# Altium schematic sheet vraimenthorspcb.SchDoc
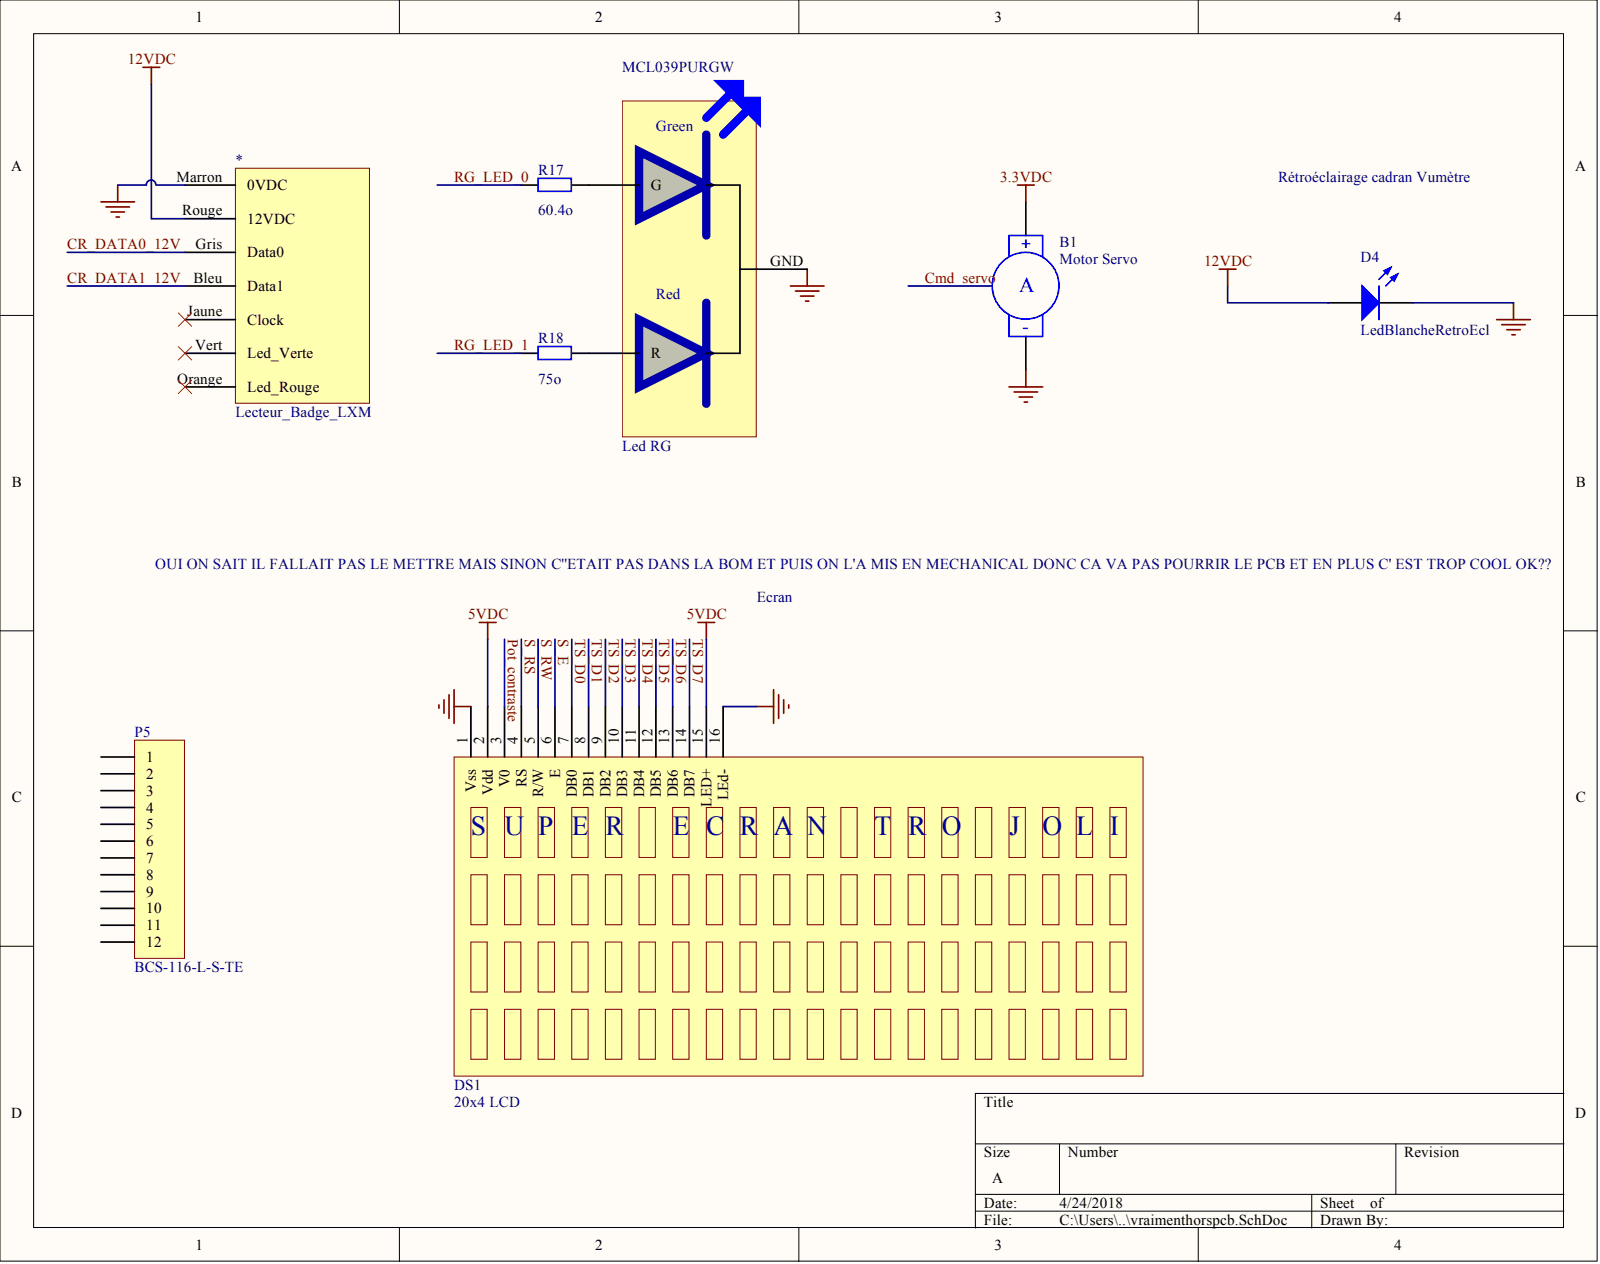
[diagram p0: vraimenthorspcb.SchDoc]

## Parts
*  Lecteur_Badge_LXM  (mechanical)
B1  Motor Servo  [RAD-0.4]  (mechanical)
D4  LedBlancheRetroEcl  [LED-0]  (mechanical)
DS1  20x4 LCD  (mechanical)
MCL039PURGW  Led RG  (mechanical)
P5  BCS-116-L-S-TE  [HDR1X12]  (mechanical)
R17  value 60.4o  [AXIAL-0.4]  (hidden comment: Res2)
R18  value 75o  [AXIAL-0.4]  (hidden comment: Res2)

## Nets
3.3VDC: B1.1
5VDC: DS1.2(Vdd) DS1.15(LED+)
12VDC: *.Rouge(12VDC) D4.1
GND: *.Marron(0VDC) B1.2 D4.2 DS1.1(Vss) DS1.16(LEd-) MCL039PURGW.GND
CR_DATA0_12V: *.Gris(Data0)
CR_DATA1_12V: *.Bleu(Data1)
POT_CONTRASTE: DS1.3(V0)
RG_LED_0: R17.1
RG_LED_1: R18.1
S_E: DS1.6(E)
S_RS: DS1.4(RS)
S_RW: DS1.5(R/W)
TS_D0: DS1.7(DB0)
TS_D1: DS1.8(DB1)
TS_D2: DS1.9(DB2)
TS_D3: DS1.10(DB3)
TS_D4: DS1.11(DB4)
TS_D5: DS1.12(DB5)
TS_D6: DS1.13(DB6)
TS_D7: DS1.14(DB7)
NetMCL039PURGW_G: MCL039PURGW.G R17.2
NetMCL039PURGW_R: MCL039PURGW.R R18.2
CMD_SERVO:

Not connected: * Jaune(Clock) Orange(Led_Rouge) Vert(Led_Verte); P5 1 2 3 4 5 6 7 8 9 10 11 12

## Library data of the parts
* = Lecteur_Badge_LXM: Fabricant: Stid-Security; Fournisseur: Gregoire; Ref.Fabricant: LXM_WIEGAND44b; Ref.Fournisseur: :); Library Name: Schlib1.SchLib
B1 = Motor Servo: Servo Motor; Fabricant: Olimex; Ref.Fabricant: MS-R-1.3-9; Fournisseur: Olimex; Library Name: Miscellaneous Devices.IntLib; Ref.Fournisseur: MS-R-1.3-9
D4 = LED0: LED Blanche retro vumetre; Fournisseur: BARBIE; Fabricant: N/A; Library Name: Miscellaneous Devices.IntLib; Ref.Fabricant: N/A; Ref.Fournisseur: N/A; Simulation: LED0
DS1 = MC42008A6W-FPTLW: Fabricant: Midas; Fournisseur: Farnell; Ref.Fournisseur: 2606880; Library Name: Schlib1.SchLib; Ref.Fabricant: MC42008A6W-FPTLW
MCL039PURGW = MCL039PURGW: Fabricant: Multicomp; Fournisseur: Farnell; Ref.Fournisseur: 1581194; Description: Led RG; Library Name: Schlib1.SchLib; Ref.Fabricant: MCL039PURGW
P5 = Header 12: Header, 12-Pin; Fabricant: Samtec; Fournisseur: Farnell; Ref.Fournisseur: 1667483; Library Name: Miscellaneous Connectors.IntLib; Ref.Fabricant: BCS-116-L-S-TE; Signal Integrity: Connector
R17 = Res2: Resistor; Fabricant: TE CONNECTIVITY; Fournisseur: Farnell; Ref.Fabricant: RP73PF1E60R4BTDF; Ref.Fournisseur: 2838800; Component Kind: Standard; Library Name: Miscellaneous Devices.IntLib; Signal Integrity: Res; Simulation: RESISTOR
R18 = Res2: Resistor; Fabricant: TE CONNECTIVITY; Fournisseur: Farnell; Ref.Fabricant: CPF0805B75RE1; Ref.Fournisseur: 1697415; Component Kind: Standard; Library Name: Miscellaneous Devices.IntLib; Signal Integrity: Res; Simulation: RESISTOR
